# Altium schematic sheet ADC.SchDoc(U_ADC)
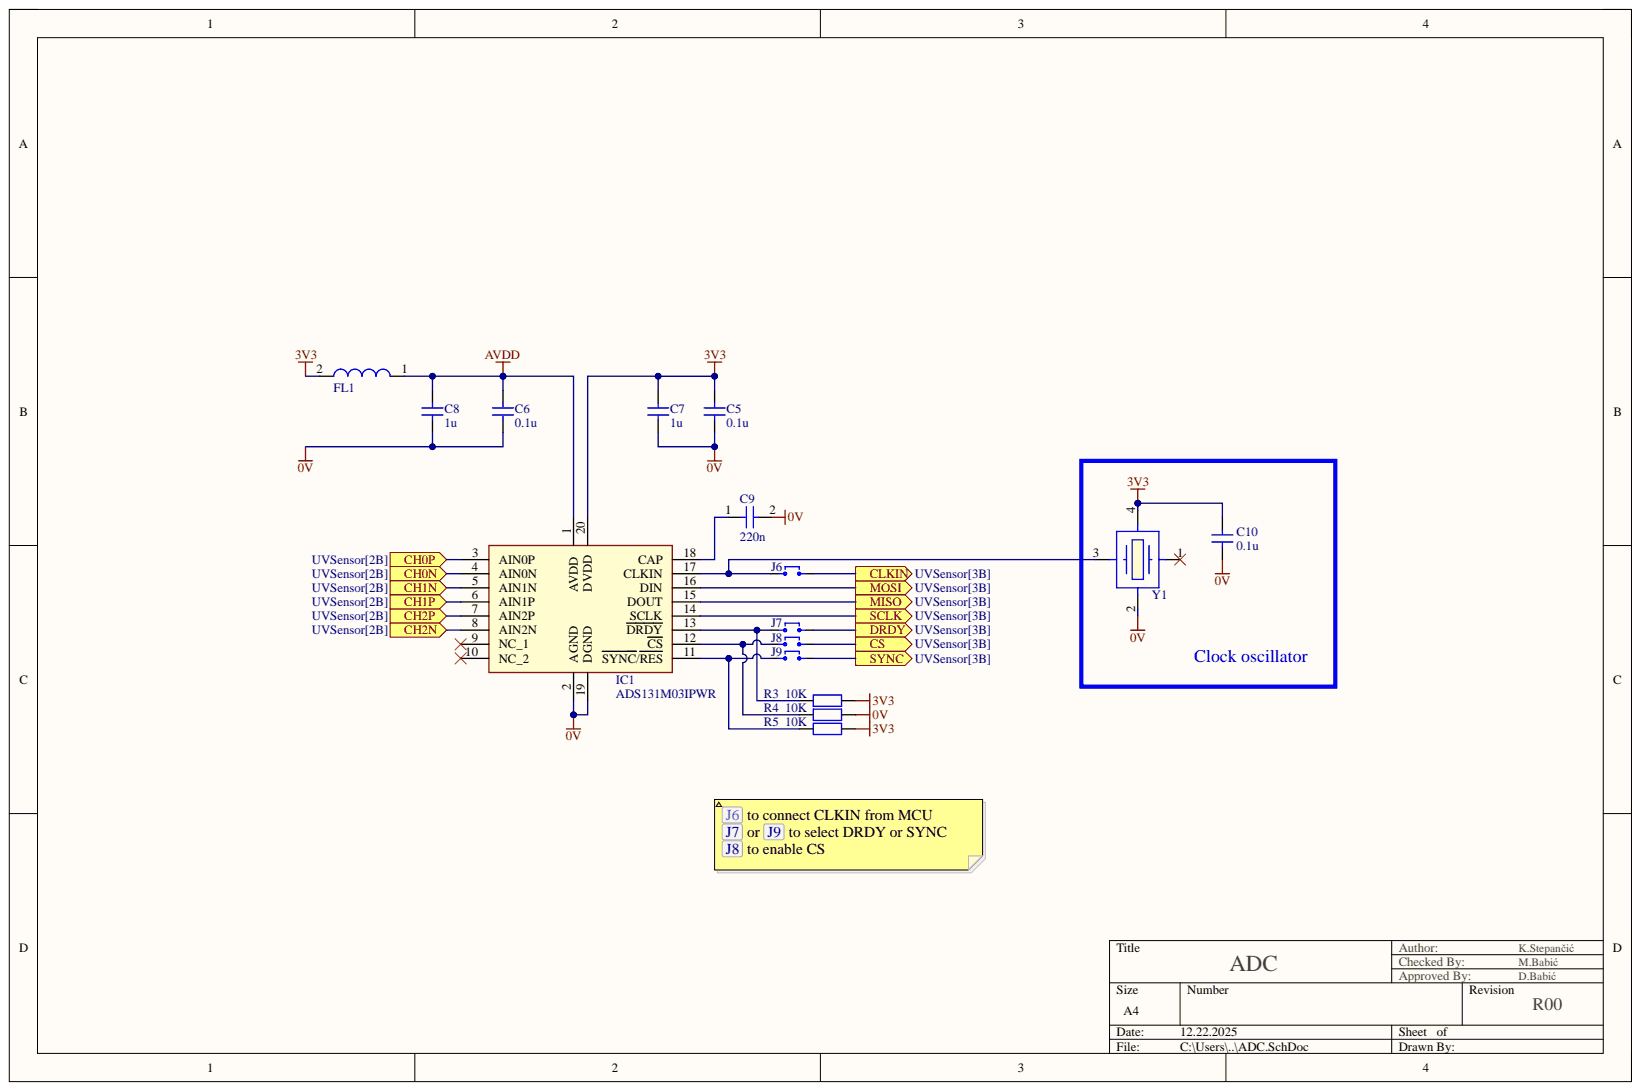
[diagram p0: ADC.SchDoc]

## Parts
C5  0.1u  [CAPC2012X14M]
C6  0.1u  [CAPC2012X14M]
C7  1u  [CAPC2012X14M]
C8  1u  [CAPC2012X14M]
C9  220n  [C_0603]
C10  0.1u  [CAPC2012X14M]
FL1  BLM21SP601BH1D  [BLM21AG102BH1J]  (value not drawn)
IC1  ADS131M03IPWR  [SOP65P640X120-20N]
J6  61300211121  [HDRV2W110P0X254_1X2_508X254X854P]  (value not drawn)
J7  61300211121  [HDRV2W110P0X254_1X2_508X254X854P]  (value not drawn)
J8  61300211121  [HDRV2W110P0X254_1X2_508X254X854P]  (value not drawn)
J9  61300211121  [HDRV2W110P0X254_1X2_508X254X854P]  (value not drawn)
R3  10K  [RESC2112X05M]
R4  10K  [RESC2112X05M]
R5  10K  [RESC2112X05M]
Y1  ECS-2520MVLC-020-BN-TR  [ECS2520MVLC020BNTR]  (value not drawn)

## Ports
CH0N, CH0P, CH1N, CH1P, CH2N, CH2P, CLKIN, CS, DRDY, MISO, MOSI, SCLK, SYNC

## Nets
0V: C5.2 C6.2 C7.2 C8.2 C9.2 C10.2 IC1.2(AGND) IC1.19(DGND) R4.2 Y1.2
3V3: C5.1 C7.1 C10.1 FL1.2 IC1.20(DVDD) R3.2 R5.2 Y1.4
AVDD: C6.1 C8.1 FL1.1 IC1.1(AVDD)
CH0N: IC1.4(AIN0N) ; port CH0N
CH0P: IC1.3(AIN0P) ; port CH0P
CH1N: IC1.5(AIN1N) ; port CH1N
CH1P: IC1.6(AIN1P) ; port CH1P
CH2N: IC1.8(AIN2N) ; port CH2N
CH2P: IC1.7(AIN2P) ; port CH2P
CLKIN: J6.2 ; port CLKIN
CS: J8.2 ; port CS
DRDY: J7.2 ; port DRDY
MISO: IC1.15(DOUT) ; port MISO
MOSI: IC1.16(DIN) ; port MOSI
SCLK: IC1.14(SCLK) ; port SCLK
SYNC: J9.2 ; port SYNC
NetC9_1: C9.1 IC1.18(CAP)
NetIC1_9: IC1.9(NC_1)
NetIC1_10: IC1.10(NC_2)
NetIC1_11: IC1.11(SYNC/RES) J9.1 R5.1
NetIC1_12: IC1.12(CS) J8.1 R4.1
NetIC1_13: IC1.13(DRDY) J7.1 R3.1
NetIC1_17: IC1.17(CLKIN) J6.1 Y1.3
NetY1_1: Y1.1

## Library data of the parts
C5 C6 C10 = CAPC2012X14M: C0805C104K5RACTU, Multilayer Ceramic Capacitors MLCC - SMD/SMT 50V 0.1uF X7R 0805 10%; Component Kind: Standard; Library Name: SensorO3.SchLib
C7 C8 = CAPC2012X14M: C0805C105K5RACTU, Multilayer Ceramic Capacitors MLCC - SMD/SMT 50V 1uF X7R 0805 10%; Component Kind: Standard; Library Name: SensorO3.SchLib
C9 = CAP_0603: Capacitor 0603; Component Kind: Standard; Library Name: UVSensor.SchLib
FL1 = BLM21SP601BH1D: BLM18PG181SN1D; Manufacturer_Name: Murata Electronics; Arrow Part Number: BLM21SP601BH1D; Arrow Price/Stock: https://www.arrow.com/en/products/blm21sp601bh1d/murata-manufacturing?region=nac; Component Kind: Standard; Datasheet Link: https://www.murata.com/en-us/products/productdetail?partno=BLM21SP601BH1%23; Height: 1.05mm; Library Name: SensorO3.SchLib; Manufacturer_Part_Number: BLM21SP601BH1D; Mouser Part Number: 81-BLM21SP601BH1D; Mouser Price/Stock: https://www.mouser.co.uk/ProductDetail/Murata-Electronics/BLM21SP601BH1D?qs=17u8i%2FzlE88DTAFtoKR6tQ%3D%3D
IC1 = ADS131M03IPWR: Integrated Circuit; Manufacturer_Name: Texas Instruments; Component Kind: Standard; Datasheet Link: https://www.ti.com/lit/ds/symlink/ads131m03.pdf?ts=1627392539825&ref_url=https%253A%252F%252Fwww.ti.com%252Fproduct%252FADS131M03%253FkeyMatch%253DADS131M03IPWR%2526tisearch%253Dsearch-everything%2526usecase%253DOPN; Height: 1.2mm; Library Name: SensorO3.SchLib; Manufacturer_Part_Number: ADS131M03IPWR; Mouser Part Number: 595-ADS131M03IPWR; Mouser Price/Stock: https://www.mouser.co.uk/ProductDetail/Texas-Instruments/ADS131M03IPWR?qs=Jslch3jnSjnSosXolUzTvg%3D%3D
J6-J9 = 61300211121: Connector; Manufacturer_Name: Wurth Elektronik; Component Kind: Standard; Datasheet Link: https://componentsearchengine.com/Datasheets/2/61300211121.pdf; Height: 8.54mm; Library Name: SensorO3.SchLib; Manufacturer_Part_Number: 61300211121; Mouser Part Number: 710-61300211121; Mouser Price/Stock: https://www.mouser.co.uk/ProductDetail/Wurth-Elektronik/61300211121?qs=t4813l51qx%252B1A5GYDQxPlw%3D%3D
R3-R5 = RESC2112X05M: CRCW080510K0FKEA, Thick Film Resistors 1/8watt 10Kohms 1% 100ppm; Component Kind: Standard; Library Name: SensorO3.SchLib
Y1 = ECS-2520MVLC-020-BN-TR: ECS-2520MVLC-081.92-BN-TR, Standard Clock Oscillators MultiVolt ECS-2520MVLC,Active,XO (Standard),8.192MHz,Enable/Disable,CMOS,1.8V, 2.5V, 3.3V,+/-50ppm,-40 C 85 C,Surface Mount,4-SMD, No Lead,0.098" L x 0.079" W (2.50mm x 2.00mm),0.035" (0.90mm); Manufacturer_Name: ECS; Arrow Part Number: ECS-2520MVLC-020-BN-TR; Arrow Price/Stock: https://www.arrow.com/en/products/ecs-2520mvlc-020-bn-tr/ecs-international?region=nac; Component Kind: Standard; Datasheet Link: https://www.mouser.tw/datasheet/2/122/ECS_2520MVLC-1903379.pdf; Height: 0.9mm; Library Name: SensorO3.SchLib; Manufacturer_Part_Number: ECS-2520MVLC-020-BN-TR; Mouser Part Number: 520-2520MVLC-020BNTR; Mouser Price/Stock: https://www.mouser.co.uk/ProductDetail/ECS/ECS-2520MVLC-020-BN-TR?qs=DPoM0jnrROWIZyauLuSe3Q%3D%3D
